# Altium schematic sheet MCU.SchDoc(MCU)
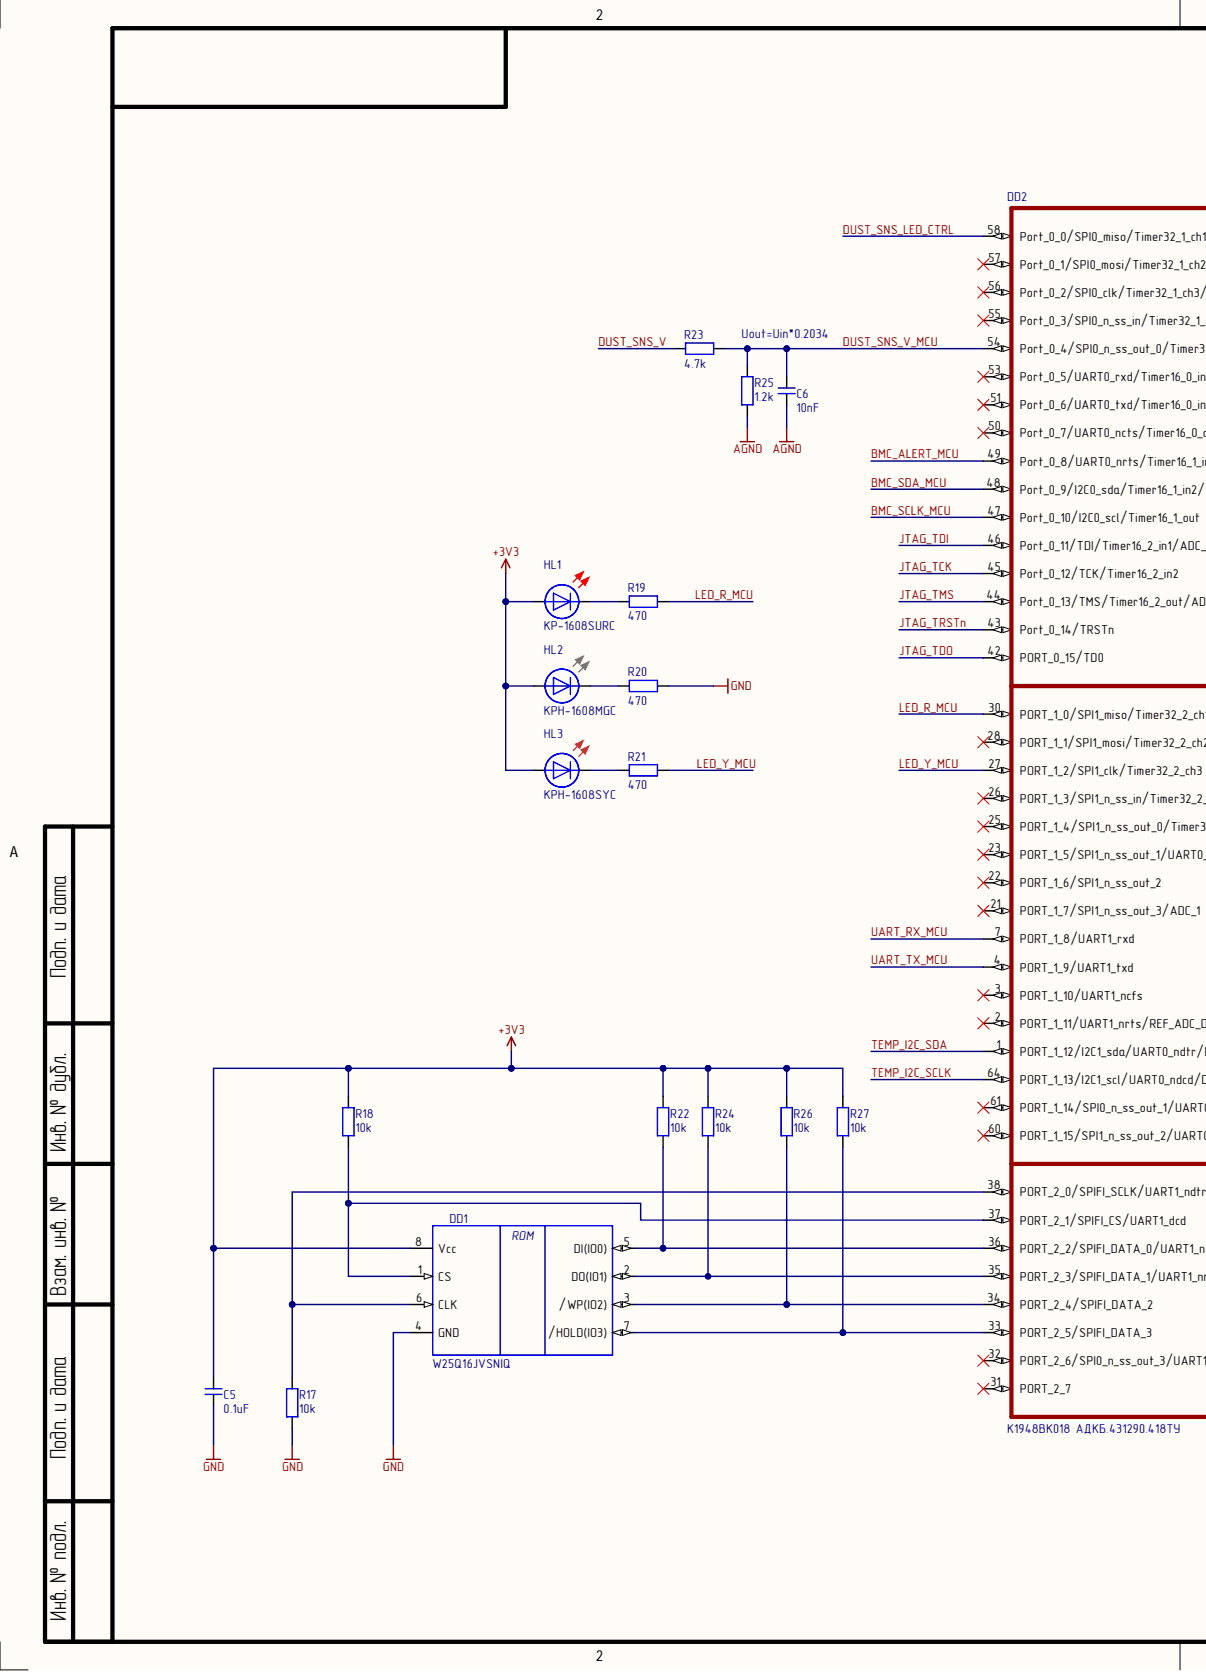
[diagram p0: MCU.SchDoc, left]
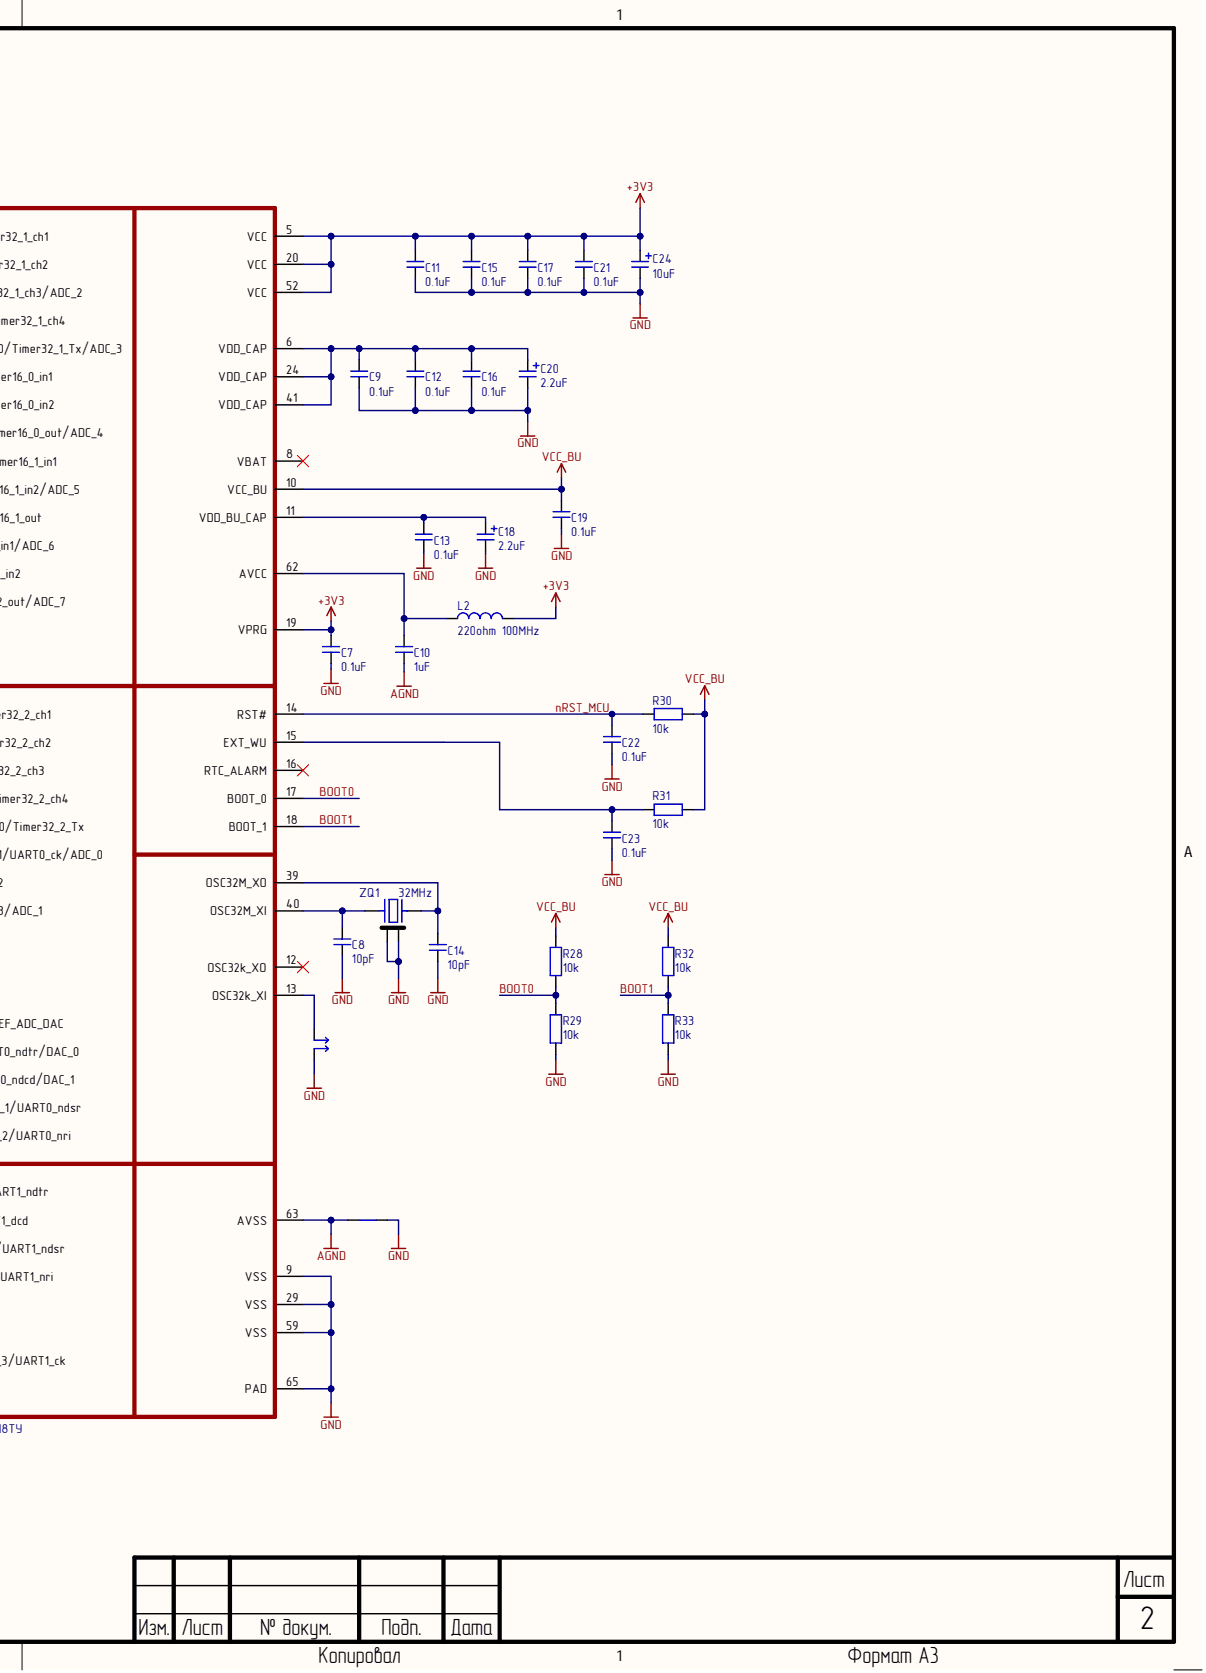
[diagram p1: MCU.SchDoc, right]

## Parts
C5  0.1uF  [CAP 0603_1608]
C6  10nF  [CAP 0603_1608]
C7  0.1uF  [CAP 0603_1608]
C8  10pF  [CAP 0603_1608]
C9  0.1uF  [CAP 0603_1608]
C10  1uF  [CAP 0805_2012]
C11  0.1uF  [CAP 0603_1608]
C12  0.1uF  [CAP 0603_1608]
C13  0.1uF  [CAP 0603_1608]
C14  10pF  [CAP 0603_1608]
C15  0.1uF  [CAP 0603_1608]
C16  0.1uF  [CAP 0603_1608]
C17  0.1uF  [CAP 0603_1608]
C18  2.2uF  [CAP ALSMD ELEC 4.3MM H5.4MM]
C19  0.1uF  [CAP 0603_1608]
C20  2.2uF  [CAP ALSMD ELEC 4.3MM H5.4MM]
C21  0.1uF  [CAP 0603_1608]
C22  0.1uF  [CAP 0603_1608]
C23  0.1uF  [CAP 0603_1608]
C24  10uF  [CAP ALSMD ELEC 4.3MM H5.4MM]
DD1  W25Q16JVSNIQ  [WINBOND SOIC-8]
DD2  К1948ВК018 АДКБ.431290.418ТУ  [QFN40P800X800X76_HS-65M]
HL1  KP-1608SURC  [LED 0603_1608 RED]
HL2  KPH-1608MGC  [LED 0603_1608 GREEN]
HL3  KPH-1608SYC  [LED 0603_1608 YELLOW]
J1  =Value  [NET_TIE_1MM]
L2  220ohm 100MHz  [FER 0805_2012]
R17  10k  [RES 0603_1608]
R18  10k  [RES 0603_1608]
R19  470  [RES 0603_1608]
R20  470  [RES 0603_1608]
R21  470  [RES 0603_1608]
R22  10k  [RES 0603_1608]
R23  4.7k  [RES 0603_1608]
R24  10k  [RES 0603_1608]
R25  1.2k  [RES 0603_1608]
R26  10k  [RES 0603_1608]
R27  10k  [RES 0603_1608]
R28  10k  [RES 0603_1608]
R29  10k  [RES 0603_1608]
R30  10k  [RES 0603_1608]
R31  10k  [RES 0603_1608]
R32  10k  [RES 0603_1608]
R33  10k  [RES 0603_1608]
X1  =Value  [Jumper]  (no BOM)
ZQ1  32MHz  [XTAL3225X07N]

## Nets
+3V3: C5.2 C7.2 C11.2 C15.2 C17.2 C21.2 C24.1 DD1.8(Vcc) DD2.5(VCC) DD2.19(VPRG) DD2.20(VCC) DD2.52(VCC) HL1.1 HL2.1 HL3.1 L2.2 R18.1 R22.2 R24.2 R26.2 R27.2
AGND: C6.1 C10.1 DD2.63(AVSS) J1.1 R25.1
GND: C5.1 C7.1 C8.1 C9.1 C11.1 C12.1 C13.1 C14.1 C15.1 C16.1 C17.1 C18.2 C19.1 C20.2 C21.1 C22.1 C23.1 C24.2 DD1.4(GND) DD2.9(VSS) DD2.29(VSS) DD2.59(VSS) DD2.65(PAD) J1.2 R17.1 R20.2 R29.1 R33.1 X1.2 ZQ1.2 ZQ1.4
VCC_BU: C19.2 DD2.10(VCC_BU) R28.2 R30.1 R31.1 R32.2
BMC_ALERT_MCU: DD2.49(Port_0_8/UART0_nrts/Timer16_1_in1)
BMC_SCLK_MCU: DD2.47(Port_0_10/I2C0_scl/Timer16_1_out)
BMC_SDA_MCU: DD2.48(Port_0_9/I2C0_sda/Timer16_1_in2/ADC_5)
BOOT0: DD2.17(BOOT_0) R28.1 R29.2
BOOT1: DD2.18(BOOT_1) R32.1 R33.2
DUST_SNS_LED_CTRL: DD2.58(Port_0_0/SPI0_miso/Timer32_1_ch1)
DUST_SNS_V: R23.1
DUST_SNS_V_MCU: C6.2 DD2.54(Port_0_4/SPI0_n_ss_out_0/Timer32_1_Tx/ADC_3) R23.2 R25.2
JTAG_TCK: DD2.45(Port_0_12/TCK/Timer16_2_in2)
JTAG_TDI: DD2.46(Port_0_11/TDI/Timer16_2_in1/ADC_6)
JTAG_TDO: DD2.42
JTAG_TMS: DD2.44(Port_0_13/TMS/Timer16_2_out/ADC_7)
JTAG_TRSTn: DD2.43(Port_0_14/TRSTn)
LED_R_MCU: DD2.30(PORT_1_0/SPI1_miso/Timer32_2_ch1) R19.2
LED_Y_MCU: DD2.27(PORT_1_2/SPI1_clk/Timer32_2_ch3) R21.2
nRST_MCU: C22.2 DD2.14(RST#) R30.2
TEMP_I2C_SCLK: DD2.64(PORT_1_13/I2C1_scl/UART0_ndcd/DAC_1)
TEMP_I2C_SDA: DD2.1(PORT_1_12/I2C1_sda/UART0_ndtr/DAC_0)
UART_RX_MCU: DD2.7(PORT_1_8/UART1_rxd)
UART_TX_MCU: DD2.4(PORT_1_9/UART1_txd)
NetC8_2: C8.2 DD2.40(OSC32M_XI) ZQ1.1
NetC9_2: C9.2 C12.2 C16.2 C20.1 DD2.6(VDD_CAP) DD2.24(VDD_CAP) DD2.41(VDD_CAP)
NetC10_2: C10.2 DD2.62(AVCC) L2.1
NetC13_2: C13.2 C18.1 DD2.11(VDD_BU_CAP)
NetC14_2: C14.2 DD2.39(OSC32M_XO) ZQ1.3
NetC23_2: C23.2 DD2.15(EXT_WU) R31.2
NetDD1_1: DD1.1(CS) DD2.37(PORT_2_1/SPIFI_CS/UART1_dcd) R18.2
NetDD1_2: DD1.2(DO(IO1)) DD2.35(PORT_2_3/SPIFI_DATA_1/UART1_nri) R24.1
NetDD1_3: DD1.3(/WP(IO2)) DD2.34(PORT_2_4/SPIFI_DATA_2) R26.1
NetDD1_5: DD1.5(DI(IO0)) DD2.36(PORT_2_2/SPIFI_DATA_0/UART1_ndsr) R22.1
NetDD1_6: DD1.6(CLK) DD2.38(PORT_2_0/SPIFI_SCLK/UART1_ndtr) R17.2
NetDD1_7: DD1.7(/HOLD(IO3)) DD2.33(PORT_2_5/SPIFI_DATA_3) R27.1
NetDD2_13: DD2.13(OSC32k_XI) X1.1
NetHL1_2: HL1.2 R19.1
NetHL2_2: HL2.2 R20.1
NetHL3_2: HL3.2 R21.1

Not connected: DD2 2(PORT_1_11/UART1_nrts/REF_ADC_DAC) 3(PORT_1_10/UART1_ncfs) 8(VBAT) 12(OSC32k_XO) 16(RTC_ALARM) 21(PORT_1_7/SPI1_n_ss_out_3/ADC_1) 22(PORT_1_6/SPI1_n_ss_out_2) 23(PORT_1_5/SPI1_n_ss_out_1/UART0_ck/ADC_0) 25(PORT_1_4/SPI1_n_ss_out_0/Timer32_2_Tx) 26(PORT_1_3/SPI1_n_ss_in/Timer32_2_ch4) 28(PORT_1_1/SPI1_mosi/Timer32_2_ch2) 31(PORT_2_7) 32(PORT_2_6/SPI0_n_ss_out_3/UART1_ck) 50(Port_0_7/UART0_ncts/Timer16_0_out/ADC_4) 51(Port_0_6/UART0_txd/Timer16_0_in2) 53(Port_0_5/UART0_rxd/Timer16_0_in1) 55(Port_0_3/SPI0_n_ss_in/Timer32_1_ch4) 56(Port_0_2/SPI0_clk/Timer32_1_ch3/ADC_2) 57(Port_0_1/SPI0_mosi/Timer32_1_ch2) 60(PORT_1_15/SPI1_n_ss_out_2/UART0_nri) 61(PORT_1_14/SPI0_n_ss_out_1/UART0_ndsr)

## Library data of the parts
C5 C7 C9 C11-C13 C15-C17 C19 C21-C23 = GRM188R71E104K: Конденсатор 0603-X7R-25В-0,1мкФ ± 10%; Manufacturer: Murata Electronics; Database Table Name: capacitors.csv; Dielectric type: X7R; Group: Конденсаторы; Type: Конденсатор керамический; Voltage: 25V; Component Kind: Standard; Country: Япония; Library Name: ComponentsDb.DbLib; Manufacturer Part Number: GRM188R71E104K; Name: GRM188R71E104K; Package: SMD0603; Tolerance: ± 10%; Value: 0.1uF
C6 = GRM188R71E103K: Конденсатор 0603-X7R-25В-10мкФ ± 10%; Manufacturer: Murata Electronics; Database Table Name: capacitors.csv; Dielectric type: X7R; Group: Конденсаторы; Type: Конденсатор керамический; Voltage: 25V; Component Kind: Standard; Country: Япония; Library Name: ComponentsDb.DbLib; Manufacturer Part Number: GRM188R71E103K; Name: GRM188R71E103K; Package: SMD0603; Tolerance: ± 10%; Value: 10nF
C8 C14 = CC0603KRNPO9BN100: Конденсатор 0603-C0G-50В-10пФ ± 10%; Manufacturer: Yageo; Database Table Name: capacitors.csv; Dielectric type: C0G; Group: Конденсаторы; Type: Конденсатор керамический; Voltage: 50V; Component Kind: Standard; Country: Тайвань; Library Name: ComponentsDb.DbLib; Manufacturer Part Number: CC0603KRNPO9BN100; Name: CC0603KRNPO9BN100; Package: SMD0603; Tolerance: ± 10%; Value: 10pF
C10 = GRM21BR71E105KA99L: Конденсатор 0805-X7R-25В-1мкФ ± 10%; Manufacturer: Murata Electronics; Database Table Name: capacitors.csv; Dielectric type: X7R; Group: Конденсаторы; Type: Конденсатор керамический; Voltage: 25V; Component Kind: Standard; Country: Япония; Library Name: ComponentsDb.DbLib; Manufacturer Part Number: GRM21BR71E105KA99L; Name: GRM21BR71E105KA99L; Package: SMD0805; Tolerance: ± 10%; Value: 1uF
C18 C20 = К50-97 – 50В – 2,2мкФ (±20) % – ЕВАЯ.673541.054ТУ: Конденсатор К50-97 – 50В – 2,2мкФ (±20) % – ЕВАЯ.673541.054ТУ; Manufacturer: АО Элеконд; Database Table Name: capacitors.csv; Dielectric type: Electrolytic; Group: Конденсаторы полярные; Tolerance: ± 20%; Type: Конденсатор электролитический; Voltage: 50V; Component Kind: Standard; Country: Россия; HelpURL: https://elecond.ru/pdf/k50-97.pdf; Library Name: ComponentsDb.DbLib; Manufacturer Part Number: К50-97 – 50В – 2,2мкФ (±20) % – ЕВАЯ.673541.054ТУ; Name: К50-97 – 50В – 2,2мкФ (±20) % – ЕВАЯ.673541.054ТУ; Package: SMD D4x5.4; Value: 2.2uF
C24 = К50-97 – 25В – 10мкФ (±20) % – ЕВАЯ.673541.054ТУ: Конденсатор К50-97 – 25В – 10мкФ (±20) % – ЕВАЯ.673541.054ТУ; Manufacturer: АО Элеконд; Database Table Name: capacitors.csv; Dielectric type: Electrolytic; Group: Конденсаторы полярные; Tolerance: ± 20%; Type: Конденсатор электролитический; Voltage: 25V; Component Kind: Standard; Country: Россия; HelpURL: https://elecond.ru/pdf/k50-97.pdf; Library Name: ComponentsDb.DbLib; Manufacturer Part Number: К50-97 – 25В – 10мкФ (±20) % – ЕВАЯ.673541.054ТУ; Name: К50-97 – 25В – 10мкФ (±20) % – ЕВАЯ.673541.054ТУ; Package: SMD D4x5.4; Value: 10uF
DD1 = W25Q16JVSNIQ: Память Flash W25Q16JVSNIQ; Manufacturer: Winbond; Database Table Name: digital_ics.csv; Group: Микросхемы; Max Temperature: 85°С; Min Temperature: -40°С; Notes: 16Mb(2M x 8); Supply Voltage: 3,3V; Component Kind: Standard; Country: Тайвань; HelpURL: https://static.chipdip.ru/lib/136/DOC012136857.pdf; Library Name: ComponentsDb.DbLib; Manufacturer Part Number: W25Q16JVSNIQ; Name: W25Q16JVSNIQ; Package: SOIC-8; Type: Память Flash
DD2 = К1948ВК018 АДКБ.431290.418ТУ: Микроконтроллер К1948ВК018 АДКБ.431290.418ТУ; Manufacturer: АО «МИКРОН»; Database Table Name: digital_ics.csv; Group: Микросхемы; Max Temperature: 85°С; Min Temperature: -45°С; Supply Voltage: 3,3V; Component Kind: Standard; Country: Россия; HelpURL: https://mikron.ru/upload/docs/mcu/Information_AMUR_MIK32.pdf; Library Name: ComponentsDb.DbLib; Manufacturer Part Number: К1948ВК018 АДКБ.431290.418ТУ; Name: К1948ВК018 АДКБ.431290.418ТУ; Package: QFN40; Type: Микроконтроллер
HL1 = KP-1608SURC: Светодиод KP-1608SURC; Manufacturer: Kingbright; Database Table Name: diodes.csv; Group: Светодиоды; Max If: 20mA; Max Vr: 5V; Component Kind: Standard; Country: Тайвань; Library Name: ComponentsDb.DbLib; Manufacturer Part Number: KP-1608SURC; Name: KP-1608SURC; Package: SMD1608; Type: Светодиод
HL2 = KPH-1608MGC: Светодиод KPH-1608MGC; Manufacturer: Kingbright; Database Table Name: diodes.csv; Group: Светодиоды; Max If: 20mA; Max Vr: 5V; Component Kind: Standard; Country: Тайвань; Library Name: ComponentsDb.DbLib; Manufacturer Part Number: KPH-1608MGC; Name: KPH-1608MGC; Package: SMD1608; Type: Светодиод
HL3 = KPH-1608SYC: Светодиод KPH-1608SYC; Manufacturer: Kingbright; Database Table Name: diodes.csv; Group: Светодиоды; Max If: 20mA; Max Vr: 5V; Component Kind: Standard; Country: Тайвань; Library Name: ComponentsDb.DbLib; Manufacturer Part Number: KPH-1608SYC; Name: KPH-1608SYC; Package: SMD1608; Type: Светодиод
J1 = NET_TIE_1MM: Перемычка SMD NET_TIE_1MM; Database Table Name: connectors.csv; Group: Перемычки; Component Kind: Net Tie; Library Name: ComponentsDb.DbLib; Manufacturer Part Number: NET_TIE_1MM; Name: NET_TIE_1MM; Type: Перемычка SMD
L2 = UPZ2012U221-3R0TF: Индуктивность ЭМП 0805-3A-220Ом ± 25% 100MHz; Manufacturer: Sunlord; Current: 3A; Database Table Name: inductors.csv; Group: Индуктивности; Rdc: 40mОm; Type: Дроссель ЭМП; Component Kind: Standard; Country: Китай; HelpURL: https://static.chipdip.ru/lib/840/DOC012840723.pdf; Library Name: ComponentsDb.DbLib; Manufacturer Part Number: UPZ2012U221-3R0TF; Name: UPZ2012U221-3R0TF; Package: SMD0805; Tolerance: ±25%; Value: 220ohm 100MHz
R17 R18 R22 R24 R26-R33 = Резистор Р1-12 - 0,1 - 10кОм - ±1 % - У - "А" АЛЯР.434110.005 ТУ: Manufacturer: АО «НПО «ЭРКОН»; Database Table Name: resistors.csv; Group: Резисторы; Power: 0.1W; Tolerance: ± 1%; Voltage: 50V; Component Kind: Standard; Country: Россия; Description: Резистор Р1-12 - 0,1 - 10кОм - ±1 % - У - "А" АЛЯР.434110.005 ТУ; HelpURL: https://www.erkon-nn.ru/upload/model_library/R1-12/r1-12_5.pdf; Library Name: ComponentsDb.DbLib; Manufacturer Part Number: Резистор Р1-12 - 0,1 - 10кОм - ±1 % - У - "А" АЛЯР.434110.005 ТУ; Name: Р1-12 - 0,1 - 10кОм - ±1 % - У - "А" АЛЯР.434110.005 ТУ; Package: SMD0603; Type: Резистор; Value: 10k
R19-R21 = Резистор Р1-12 - 0,1 - 470Ом - ±1 % - У - "А" АЛЯР.434110.005 ТУ: Manufacturer: АО «НПО «ЭРКОН»; Database Table Name: resistors.csv; Group: Резисторы; Power: 0.1W; Tolerance: ± 1%; Voltage: 50V; Component Kind: Standard; Country: Россия; Description: Резистор Р1-12 - 0,1 - 470Ом - ±1 % - У - "А" АЛЯР.434110.005 ТУ; HelpURL: https://www.erkon-nn.ru/upload/model_library/R1-12/r1-12_5.pdf; Library Name: ComponentsDb.DbLib; Manufacturer Part Number: Резистор Р1-12 - 0,1 - 470Ом - ±1 % - У - "А" АЛЯР.434110.005 ТУ; Name: Р1-12 - 0,1 - 470Ом - ±1 % - У - "А" АЛЯР.434110.005 ТУ; Package: SMD0603; Type: Резистор; Value: 470
R23 = Резистор Р1-12 - 0,1 - 4,7кОм - ±1 % - У - "А" АЛЯР.434110.005 ТУ: Manufacturer: АО «НПО «ЭРКОН»; Database Table Name: resistors.csv; Group: Резисторы; Power: 0.1W; Tolerance: ± 1%; Voltage: 50V; Component Kind: Standard; Country: Россия; Description: Резистор Р1-12 - 0,1 - 4,7кОм - ±1 % - У - "А" АЛЯР.434110.005 ТУ; HelpURL: https://www.erkon-nn.ru/upload/model_library/R1-12/r1-12_5.pdf; Library Name: ComponentsDb.DbLib; Manufacturer Part Number: Резистор Р1-12 - 0,1 - 4,7кОм - ±1 % - У - "А" АЛЯР.434110.005 ТУ; Name: Р1-12 - 0,1 - 4,7кОм - ±1 % - У - "А" АЛЯР.434110.005 ТУ; Package: SMD0603; Type: Резистор; Value: 4.7k
R25 = Резистор Р1-12 - 0,1 - 1,2кОм - ±1 % - У - "А" АЛЯР.434110.005 ТУ: Manufacturer: АО «НПО «ЭРКОН»; Database Table Name: resistors.csv; Group: Резисторы; Power: 0.1W; Tolerance: ± 1%; Voltage: 50V; Component Kind: Standard; Country: Россия; Description: Резистор Р1-12 - 0,1 - 1,2кОм - ±1 % - У - "А" АЛЯР.434110.005 ТУ; HelpURL: https://www.erkon-nn.ru/upload/model_library/R1-12/r1-12_5.pdf; Library Name: ComponentsDb.DbLib; Manufacturer Part Number: Резистор Р1-12 - 0,1 - 1,2кОм - ±1 % - У - "А" АЛЯР.434110.005 ТУ; Name: Р1-12 - 0,1 - 1,2кОм - ±1 % - У - "А" АЛЯР.434110.005 ТУ; Package: SMD0603; Type: Резистор; Value: 1.2k
X1 = Jumper: Manufacturer: No; Database Table Name: connectors.csv; Group: Перемычки; Country: No; Description: Jumper; Library Name: ComponentsDb.DbLib; Manufacturer Part Number: Jumper; Name: Jumper; Type: Перемычка SMD
ZQ1 = SJK-7U-32.000-10-10-40-C-10: Резонатор кварцевый SJK-7U-32.000-10-10-40-C-10; Manufacturer: SJK; Database Table Name: crystals.csv; ESR: 60Ohm; Group: Кварцы; Load Capacity: 10pF; Tolerance: ±30ppm; Component Kind: Standard; Country: Китай; Freq: 32MHz; HelpURL: https://static.chipdip.ru/lib/087/DOC053087027.pdf; Library Name: ComponentsDb.DbLib; Manufacturer Part Number: SJK-7U-32.000-10-10-40-C-10; Name: SJK-7U-32.000-10-10-40-C-10; Package: SMD32x25x07; Type: Кварцевый резонатор; Value: 32MHz
